# Altium schematic sheet Sheet1.SchDoc
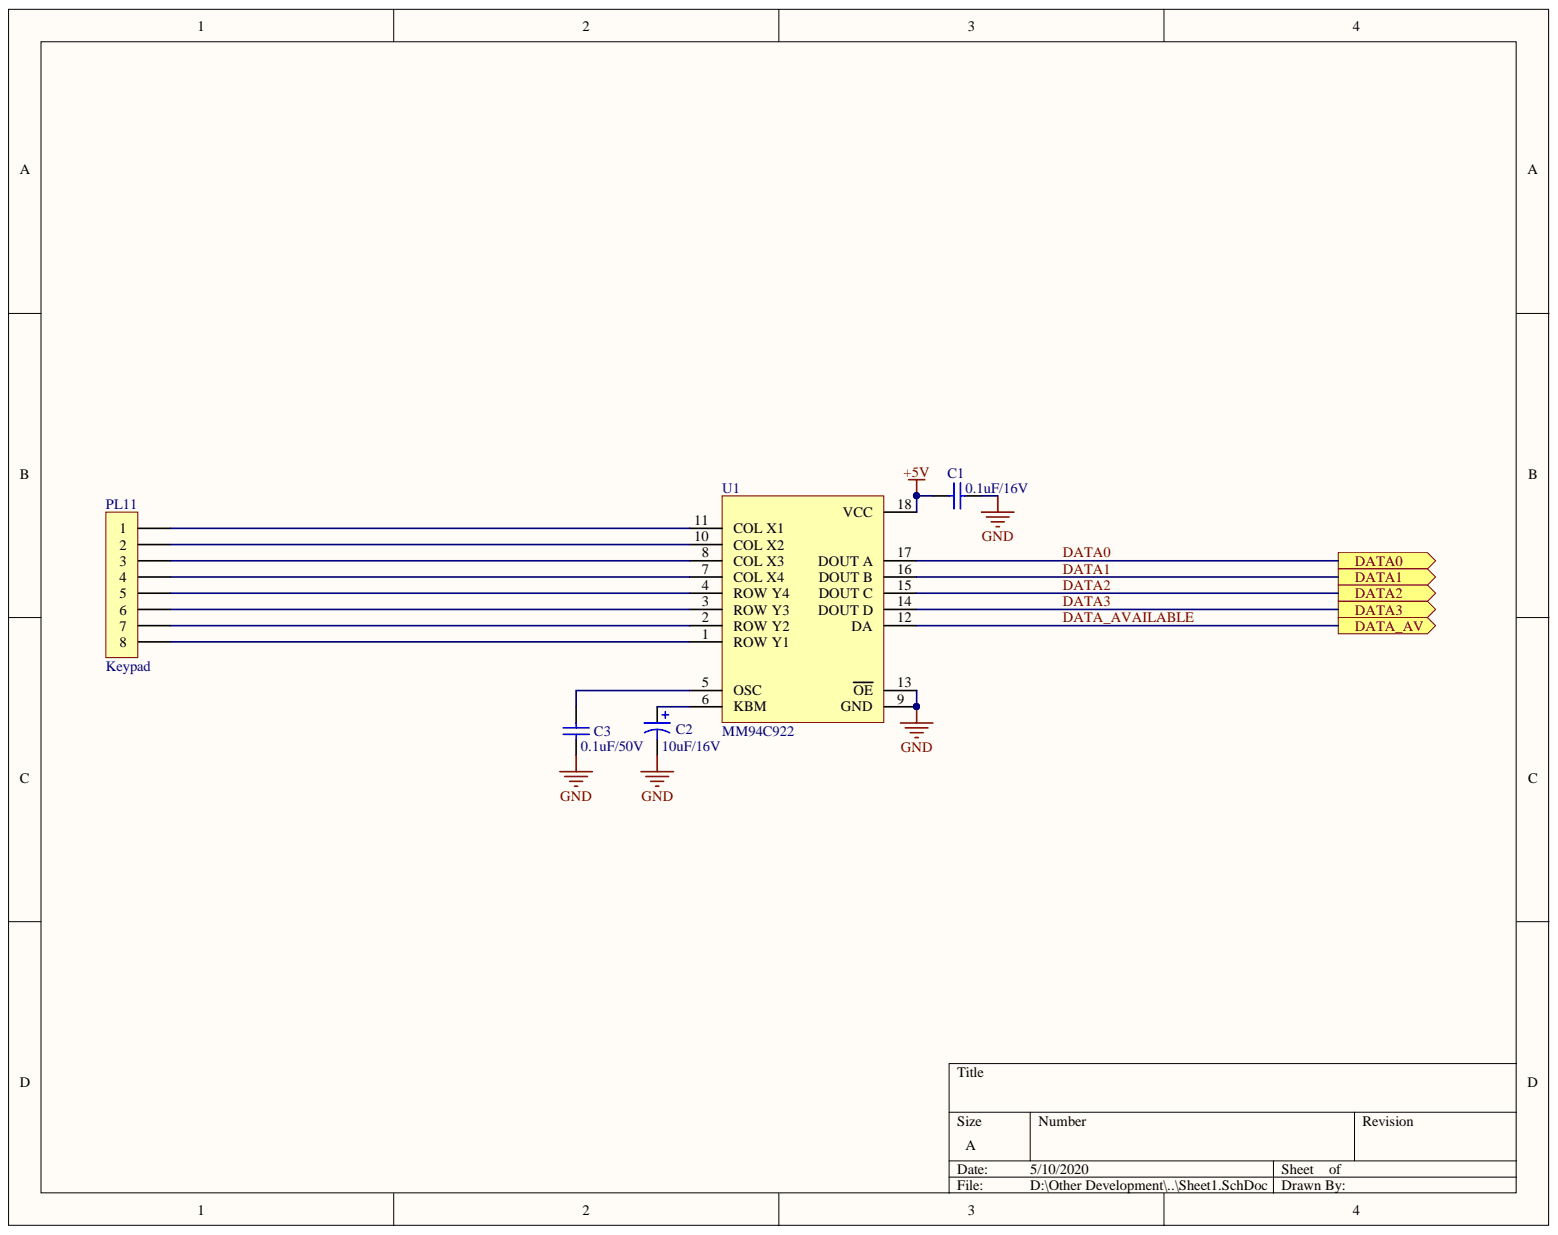
[diagram p0: Sheet1.SchDoc]

## Parts
C1  value 0.1uF/16V  [CapRad]  (hidden comment: Cap)
C2  value 10uF/16V  [CapPol100W]  (hidden comment: Cap Pol1)
C3  value 0.1uF/50V  [CapRad-W]  (hidden comment: Cap)
PL11  Keypad  [JST-XH-8]
U1  MM94C922

## Ports
DATA0, DATA1, DATA2, DATA3, DATA_AV

## Nets
+5V: C1.2 U1.18(VCC)
GND: C1.1 C2.2 C3.1 U1.9(GND) U1.13(OE)
DATA0: U1.17(DOUT_A) ; port DATA0
DATA1: U1.16(DOUT_B) ; port DATA1
DATA2: U1.15(DOUT_C) ; port DATA2
DATA3: U1.14(DOUT_D) ; port DATA3
DATA_AVAILABLE: U1.12(DA) ; port DATA_AV
NetC2_1: C2.1 U1.6(KBM)
NetC3_2: C3.2 U1.5(OSC)
NetPL11_1: PL11.1 U1.11(COL_X1)
NetPL11_2: PL11.2 U1.10(COL_X2)
NetPL11_3: PL11.3 U1.8(COL_X3)
NetPL11_4: PL11.4 U1.7(COL_X4)
NetPL11_5: PL11.5 U1.4(ROW_Y4)
NetPL11_6: PL11.6 U1.3(ROW_Y3)
NetPL11_7: PL11.7 U1.2(ROW_Y2)
NetPL11_8: PL11.8 U1.1(ROW_Y1)

## Library data of the parts
C1 C3 = Cap: Capacitor; Component Kind: Standard; LatestRevisionDate: 17-Jul-2002; LatestRevisionNote: Re-released for DXP Platform.; Library Name: Miscellaneous Devices.IntLib; PackageDescription: Radial Cap, Thru-Hole; 2 Leads; 0.3 in Pin Spacing; PackageReference: RAD-0.3; Published: 8-Jun-2000; Publisher: Altium Limited
  C1: Signal Integrity: Cap; Simulation: CAP
C2 = Cap Pol1: Polarized Capacitor (Radial); Component Kind: Standard; LatestRevisionDate: 17-Jul-2002; LatestRevisionNote: Re-released for DXP Platform.; Library Name: Miscellaneous Devices.IntLib; PackageDescription: Polarized Capacitor (Radial); 2 Leads; PackageReference: RB7.6-15; Published: 8-Jun-2000; Publisher: Altium Limited
PL11 = JST XH 2.54_5: 8 Pin Connector; Component Kind: Standard; Library Name: Schlib1.SchLib
U1 = MM94C922: Component Kind: Standard; Library Name: Schlib1.SchLib
